# Altium schematic sheet VOLTAGECURRENT.SchDoc
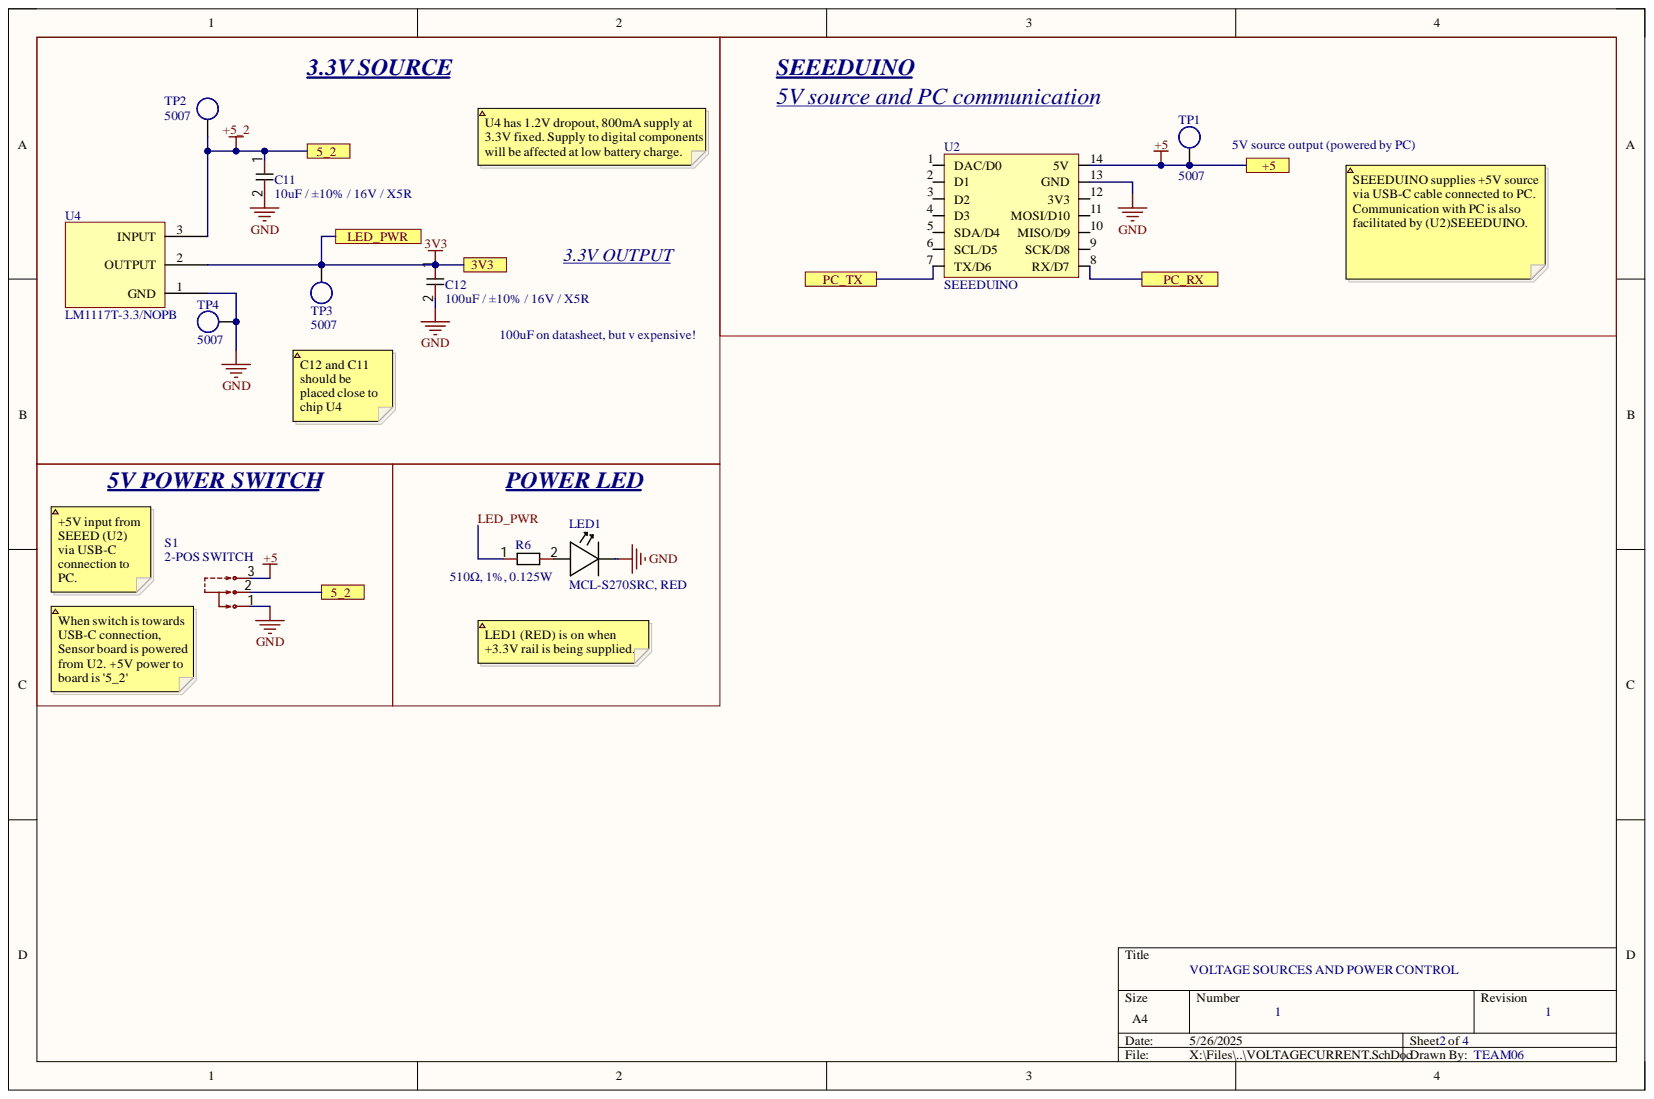
[diagram p0: VOLTAGECURRENT.SchDoc]

## Parts
C11  10uF / ±10% / 16V / X5R  [SURFM CAPACITOR]
C12  100uF / ±10% / 16V / X5R  [SURFM CAPACITOR]
LED1  MCL-S270SRC, RED  [LED SURFACE MOUNT]
R6  510Ω, 1%, 0.125W  [SURFM RESISTOR]
S1  2-POS SWITCH  [SWICH]
TP1  5007  [TEST POINT]
TP2  5007  [TEST POINT]
TP3  5007  [TEST POINT]
TP4  5007  [TEST POINT]
U2  SEEEDUINO  [XIAO]
U4  LM1117T-3.3/NOPB  [VOLTAGE REGULATOR]

## Ports
3V3, 5_2, +5, LED_PWR, PC_RX, PC_TX

## Nets
GND: C11.2 C12.2 LED1.1 S1.1 TP4.1 U2.13(GND) U4.1
3V3: C12.1 TP3.1 U4.2 ; port 3V3, port LED_PWR
+5: S1.3 TP1.1 U2.14(5V) ; port +5
+5_2: C11.1 S1.2 TP2.1 U4.3 ; port 5_2
LED_PWR: R6.1
PC_RX: U2.8(RX/D7) ; port PC_RX
PC_TX: U2.7(TX/D6) ; port PC_TX
NetLED1_2: LED1.2 R6.2

Not connected: U2 1(DAC/D0) 2(D1) 3(D2) 4(D3) 5(SDA/D4) 6(SCL/D5) 9(SCK/D8) 10(MISO/D9) 11(MOSI/D10) 12(3V3)

## Library data of the parts
C11 = 0805-C: CL21A106KOQNNNG 10 µF ±10% 16V Ceramic Capacitor X5R 0805 (2012 Metric); Component Kind: Standard; Library Name: Control_unit.SchLib
C12 = 0805-C: Component Kind: Standard; Library Name: Control_unit.SchLib
LED1 = MCL-S270SRC: Led, SMD, 0805, Super-red; Manufacturer: Multicomp; Current Rating: 20mA; Dominant Wavelength: 643nm; Forward Voltage: 1.85V; Lens Style: Rectangular; Luminous Intensity: 17mcd; Max Power Dissipation: 100mW; Viewing Angle: 140°; Case/Package: 0805; Category: LEDs; Color: Red; Component Kind: Standard; ComponentLink1Description: https://datasheet.ciiva.com/23621/53023-23621319.pdf?src-supplier=Newark; ComponentLink1URL: https://datasheet.ciiva.com/23621/53023-23621319.pdf?src-supplier=Newark; ComponentLink2Description: https://datasheet.ciiva.com/8028/1671534-8028336.pdf?src-supplier=Element14; ComponentLink2URL: https://datasheet.ciiva.com/8028/1671534-8028336.pdf?src-supplier=Element14; Forward Current: 20mA; Library Name: Control_unit.SchLib; Manufacturer Part Number: MCL-S270SRC; Max Operating Temperature: 85°C; Min Operating Temperature: -40°C; Mount: Surface Mount; Number of Pins: 2; Packaging: Cut Tape; Power Dissipation: 100mW; Pricing 1: 5=0.101, 10=0.0866, 100=0.0673, 500=0.0551, 1000=0.0505 (GBP); Reach SVHC Compliant: No; RoHS: Yes; Supplier 1: Farnell; Supplier Part Number 1: 1581239; Wavelength: 643nm
R6 = 0805-R: RES Thick Film, 510Ω, 1%, 0.125W, 100ppm/°C, 0805 CRCW0805510RFKEA; Component Kind: Standard; Library Name: Control_unit.SchLib
S1 = SWICH: Component Kind: Standard; Library Name: Control_unit.SchLib
TP1-TP4 = 5007: MS27478 - Connectors Receptacle Electrical Solder Mounting Solder Type Hermetic Seal 38999 Series II; Manufacturer: Keystone Electronics; Body Material: Bronze,PBT; Hole Diameter: 1.6mm; Inside Diameter: 1.78mm; Insulation: Insulated; Outside Diameter: 3.18mm; Plating: Silver; Category: Connectors; Color: White; Component Kind: Standard; ComponentLink1Description: https://datasheet.ciiva.com/2917/m60-2p60-2917638.pdf?src-supplier=Digikey; ComponentLink1URL: https://datasheet.ciiva.com/2917/m60-2p60-2917638.pdf?src-supplier=Digikey; ComponentLink2Description: https://datasheet.ciiva.com/22631/1703983-22631109.pdf?src-supplier=Element14; ComponentLink2URL: https://datasheet.ciiva.com/22631/1703983-22631109.pdf?src-supplier=Element14; ComponentLink3Description: https://datasheet.ciiva.com/16884/70182825-16884255.pdf?src-supplier=Allied+Electronics; ComponentLink3URL: https://datasheet.ciiva.com/16884/70182825-16884255.pdf?src-supplier=Allied+Electronics; Contact Material: Bronze; Contact Plating: Tin,Silver; Flammability Rating: UL94 V-0; Height: 0.35inch; Insulation Material: Nylon; Lead Free: Lead Free; Length: 8.89mm; Library Name: Control_unit.SchLib; Manufacturer Part Number: 5007; Material: Nylon,Bronze; Mount: PCB,Through Hole; Package Quantity: 100; Packaging: Bulk; Pricing 1: 1=0.39, 10=0.293, 25=0.2624, 100=0.2223, 500=0.18446, 1000=0.1739, 2500=0.1542, 5000=0.14312 (USD); Reach SVHC Compliant: No; RoHS: Yes; RoHS Compliant: Yes; Series: THM; Stock 1: 45397; Supplier 1: Digikey; Supplier Part Number 1: 36-5007-ND
U2 = SEEEDUINO: SAMD21 Microcontroller Development Board 0.0327MHz/48MHzCPU 32KB RAM 256KB Flash; Manufacturer: Seeed Studio; Manufacturer Part Number: 102010328; Core Architecture: ARM; Evaluation Kit: Yes; Category: DSPs - Digital Signal Processors; Component Kind: Standard; ComponentLink1Description: https://media.digikey.com/pdf/Data%20Sheets/Seeed%20Technology/102010328_Web.pdf?src-supplier=Digikey; ComponentLink1URL: https://media.digikey.com/pdf/Data%20Sheets/Seeed%20Technology/102010328_Web.pdf?src-supplier=Digikey; Library Name: Control_unit.SchLib; Pricing 1: 1=5.4 (USD); RoHS: No; Series: SAM D; Stock 1: 2211; Supplier 1: Digikey; Supplier Part Number 1: 1597-102010328-ND
U4 = LM1117T-3.3/NOPB: 800-mA 15-V linear voltage regulator ; 3-TO-220 0 to 125; Manufacturer: TI National Semiconductor; Number of Channels: 1NUMBER; Category: Voltage Regulators - Linear; Component Kind: Standard; ComponentLink1Description: https://datasheet.ciiva.com/15111/getdatasheetpartid-67377-15111321.pdf?src-supplier=Verical; ComponentLink1URL: https://datasheet.ciiva.com/15111/getdatasheetpartid-67377-15111321.pdf?src-supplier=Verical; ComponentLink2Description: https://datasheet.ciiva.com/19480/242115-19480555.pdf?src-supplier=Jameco; ComponentLink2URL: https://datasheet.ciiva.com/19480/242115-19480555.pdf?src-supplier=Jameco; ComponentLink3Description: https://datasheet.ciiva.com/41457/001013630-da-01-en-ic-reg-ldo-lm1117t-3-3-nopb-to-220-3-tid-41457409.pdf?src-supplier=Conrad; ComponentLink3URL: https://datasheet.ciiva.com/41457/001013630-da-01-en-ic-reg-ldo-lm1117t-3-3-nopb-to-220-3-tid-41457409.pdf?src-supplier=Conrad; ComponentLink4Description: https://datasheet.ciiva.com/14150/ialm1117t-e-14150010.pdf?src-supplier=Distrelec; ComponentLink4URL: https://datasheet.ciiva.com/14150/ialm1117t-e-14150010.pdf?src-supplier=Distrelec; ComponentLink5Description: https://datasheet.ciiva.com/2047/lm1117-n-2047767.pdf?src-supplier=Digikey; ComponentLink5URL: https://datasheet.ciiva.com/2047/lm1117-n-2047767.pdf?src-supplier=Digikey; ComponentLink6Description: https://datasheet.ciiva.com/1511/1882372-1511717.pdf?src-supplier=Element14; ComponentLink6URL: https://datasheet.ciiva.com/1511/1882372-1511717.pdf?src-supplier=Element14; Library Name: Control_unit.SchLib; Manufacturer Part Number: LM1117T-3.3/NOPB; Pricing 1: 1=1.69, 10=1.515, 45=1.42911, 135=1.21763, 270=1.14333, 540=1.00041, 1035=0.82891, 2520=0.77174, 5040=0.74316 (USD); RoHS: Yes; Stock 1: 4752; Supplier 1: Digikey; Supplier Part Number 1: LM1117T-3.3/NOPB-ND; Thickness: 4.572mm
